# Altium schematic sheet PixelClockController.SchDoc (continued)

## Library data of the parts
BT1 = Battery - CR16XX Retainer: BATT RETAIN COIN 1 CELL PC PIN; Component Kind: Standard; Library Name: main_library.SCHLIB; Supplier 1: Digi-Key; Supplier Part Number 1: BK-869-ND
BZ1 = Piezo Transducer: AUDIO PIEZO TRANSDUCER 12.5V SMD 9 x 9MM; Component Kind: Standard; Library Name: main_library.SCHLIB; Supplier 1: Digi-Key; Supplier Part Number 1: 490-9647-1-ND
C1-C6 C9-C11 C16 C18 = UMJ107BB7104KAHT: CAP CER 0.1UF 50V X7R 0603; Manufacturer 1: Taiyo Yuden; Database Table Name: TaiyoYuden$; Note: Approved sub OK; Tolerance: ±10%; Type: X5R; Component Kind: Standard; Library Name: Ceramic Cap DBLIB.DbLib; Manufacturer Part Number 1: UMJ107BB7104KAHT; Operating Temperature: -55°C ~ 125°C; Package: 0402; Package / Case: 0603 (1608 Metric); Revision: July-2002: Re-released for DXP Platform.; Signal Integrity: Cap Semi; Simulation: CAP; Size / Dimension: 0.063" L x 0.031" W (1.60mm x 0.80mm); Supplier 1: Digi-Key; Supplier Part Number 1: 587-4474-1-ND; Temperature Coefficient: X7R; Thickness (Max): 0.039" (1.00mm); Voltage: 50V
C7 = CapE-6.3-100uF-35V: CAP ALUM 100UF 20% 35V SMD; Note: Approved sub OK; Value: 100uF 35V; Component Kind: Standard; Library Name: main_library.SCHLIB; Published: 8-Jun-2000; Revision: July-2002: Re-released for DXP Platform.; Signal Integrity: Cap Pol; Simulation: CAP; Supplier 1: Digi-Key; Supplier Part Number 1: PCE5016CT-ND; Publisher: Altium Limited
C8 C13 C14 C42 = EMK316ABJ226KL-T: CAP CER 22UF 16V X5R 1206; Manufacturer 1: Taiyo Yuden; Database Table Name: TaiyoYuden$; Note: Approved sub OK; Tolerance: ±10%; Component Kind: Standard; Library Name: Ceramic Cap DBLIB.DbLib; Manufacturer Part Number 1: EMK316ABJ226KL-T; Operating Temperature: -55°C ~ 85°C; Package: 0402; Package / Case: 1206 (3216 Metric); Revision: July-2002: Re-released for DXP Platform.; Signal Integrity: Cap Semi; Simulation: CAP; Size / Dimension: 0.126" L x 0.063" W (3.20mm x 1.60mm); Supplier 1: Digi-Key; Supplier Part Number 1: 587-5521-1-ND; Temperature Coefficient: X5R; Thickness (Max): 0.071" (1.80mm); Type: X5R; Voltage: 16V
C12 = GMJ325KB7106KMHP: CAP CER 10UF 35V X7R 1210; Manufacturer 1: Taiyo Yuden; Database Table Name: TaiyoYuden$; Note: Approved sub OK; Tolerance: ±10%; Type: X5R; Component Kind: Standard; Library Name: Ceramic Cap DBLIB.DbLib; Manufacturer Part Number 1: GMJ325KB7106KMHP; Operating Temperature: -55°C ~ 125°C; Package: 0402; Package / Case: 1210 (3225 Metric); Revision: July-2002: Re-released for DXP Platform.; Signal Integrity: Cap Semi; Simulation: CAP; Size / Dimension: 0.126" L x 0.098" W (3.20mm x 2.50mm); Supplier 1: Digi-Key; Supplier Part Number 1: 587-4890-1-ND; Temperature Coefficient: X7R; Thickness (Max): 0.110" (2.80mm)
C15 = C1608X7R2A222K080AE: CAP CER 2700PF 50V X7R 0603; Manufacturer 1: TDK Corporation; Database Table Name: TDK$; Note: Approved sub OK; Tolerance: ±10%; Type: X5R; Voltage: 100V; Component Kind: Standard; Library Name: Ceramic Cap DBLIB.DbLib; Manufacturer Part Number 1: C1608X7R2A222K080AE; Operating Temperature: -55°C ~ 125°C; Package: 0402; Package / Case: 0603 (1608 Metric); Revision: July-2002: Re-released for DXP Platform.; Signal Integrity: Cap Semi; Simulation: CAP; Size / Dimension: 0.063" L x 0.031" W (1.60mm x 0.80mm); Supplier 1: Digi-Key; Supplier Part Number 1: 1292-1423-2-ND; Temperature Coefficient: X7R; Thickness (Max): 0.035" (0.90mm)
D1 = Diode Schottky 2A 40V (SS24FL): DIODE SCHOTTKY 40V 2A SOD123F; Manufacturer 1: ON Semiconductor; Manufacturer Part Number 1: SS24FL; Code - JEDEC: SOD-123F; Note: Approved sub OK; Component Kind: Standard; Published: 8-Jun-2000; Revision: July-2002: Re-released for DXP Platform.; Signal Integrity: Diode; Simulation: DIODE; Supplier 1: Digi-Key; Supplier Part Number 1: SS24FLCT-ND; Library Name: picoprint_library.SCHLIB; Publisher: Altium Limited
D2 = Diode SK520BTR: DIODE SCHOTTKY 200V 5A SMB; Code - JEDEC: DO-214-AB; Component Kind: Standard; Library Name: main_library.SCHLIB; Published: 8-Jun-2000; Revision: July-2002: Re-released for DXP Platform.; Supplier 1: Digi-Key; Supplier Part Number 1: 1655-1602-1-ND; Publisher: Altium Limited
L1 = Inductor SMD 3.6uH 4.9A: FIXED IND 3.6UH 4.9A 19.5 MOHM; Component Kind: Standard; Library Name: main_library.SCHLIB; Published: 24-Mar-1999; Revision: July-2002: Re-released for DXP Platform.; Supplier 1: Digi-Key; Supplier Part Number 1: 587-1998-1-ND; Publisher: Altium Limited
LD1-LD3 = LEDG  0603: LED GREEN CLEAR CHIP SMD 0603; Note: Approved sub OK; Component Kind: Standard; Library Name: main_library.SCHLIB; Supplier 1: Digi-Key; Supplier Part Number 1: 160-1435-2-ND
P1 P3 P4 P9 P11-P13 P16 P17 = Header 1X2: Header, 2-Pin; Manufacturer 1: Generic Header; Note: Approved sub OK; Library Name: main_library.SCHLIB; Signal Integrity: Connector
  P9: Component Kind: Standard
P2 P5 = Header 2X3: Header, 3-Pin, Dual row; Manufacturer 1: Generic Header; Note: Approved sub OK; Library Name: main_library.SCHLIB; Signal Integrity: Connector
P6 = Header 2X4: Header, 4-Pin, Dual row; Manufacturer 1: Generic Header; Note: Approved sub OK; Library Name: main_library.SCHLIB; Signal Integrity: Connector
P7 = Header 1X6: Header, 6-Pin; Manufacturer 1: Generic Header; Note: Approved sub OK; Component Kind: Standard; Library Name: main_library.SCHLIB; Signal Integrity: Connector
P8 = Header 10X2H: Header, 10-Pin, Dual row, Right Angle; Manufacturer 1: Generic Header; Note: Approved sub OK; Component Kind: Standard; LatestRevisionDate: 17-Jul-2002; LatestRevisionNote: Re-released for DXP Platform.; Library Name: Miscellaneous Connectors.IntLib; Signal Integrity: Connector; Publisher: Altium Limited
Q1 = MOSFET - AO3400A: N-CH MOSFET 30V 5.7A SOT23; Component Kind: Standard; Library Name: main_library.SCHLIB; Supplier 1: Digi-Key; Supplier Part Number 1: 785-1000-1-ND
R1-R4 R8-R10 R15 = RC0603FR-071KL: RES SMD 1K OHM 1% 1/10W 0603; Manufacturer 1: Yageo; Database Table Name: 0603$; Note: Approved sub OK; Power (Watts): 0.1W, 1/10W; Temperature Coefficient: ±100ppm/°C; Component Kind: Standard; Composition: Thick Film; Features: Moisture Resistant; Height - Seated (Max): 0.022" (0.55mm); Library Name: Database_Libs1.DbLib; Manufacturer Part Number 1: RC0603FR-071KL; Operating Temperature: -55°C ~ 155°C; Package / Case: 0603 (1608 Metric); Published: 8-Jun-2000; Revision: July-2002: Re-released for DXP Platform.; Signal Integrity: Res; Simulation: RESISTOR; Size / Dimension: 0.063" L x 0.031" W (1.60mm x 0.80mm); Supplier 1: Digi-Key; Supplier Part Number 1: 311-1.00KHRCT-ND; Tolerance: ±1%; Publisher: Altium Limited
R5 = RC0603FR-07330RL: RES SMD 330 OHM 1% 1/10W 0603; Manufacturer 1: Yageo; Database Table Name: 0603$; Note: Approved sub OK; Power (Watts): 0.1W, 1/10W; Temperature Coefficient: ±100ppm/°C; Component Kind: Standard; Composition: Thick Film; Features: Moisture Resistant; Height - Seated (Max): 0.022" (0.55mm); Library Name: Database_Libs1.DbLib; Manufacturer Part Number 1: RC0603FR-07330RL; Operating Temperature: -55°C ~ 155°C; Package / Case: 0603 (1608 Metric); Published: 8-Jun-2000; Revision: July-2002: Re-released for DXP Platform.; Signal Integrity: Res; Simulation: RESISTOR; Size / Dimension: 0.063" L x 0.031" W (1.60mm x 0.80mm); Supplier 1: Digi-Key; Supplier Part Number 1: 311-330HRCT-ND; Tolerance: ±1%; Publisher: Altium Limited
R6 R7 R18 R23-R25 R29-R32 = RC0603FR-0710KL: RES SMD 10K OHM 1% 1/10W 0603; Manufacturer 1: Yageo; Database Table Name: 0603$; Note: Approved sub OK; Power (Watts): 0.1W, 1/10W; Temperature Coefficient: ±100ppm/°C; Component Kind: Standard; Composition: Thick Film; Features: Moisture Resistant; Height - Seated (Max): 0.022" (0.55mm); Library Name: Database_Libs1.DbLib; Manufacturer Part Number 1: RC0603FR-0710KL; Operating Temperature: -55°C ~ 155°C; Package / Case: 0603 (1608 Metric); Published: 8-Jun-2000; Revision: July-2002: Re-released for DXP Platform.; Signal Integrity: Res; Simulation: RESISTOR; Size / Dimension: 0.063" L x 0.031" W (1.60mm x 0.80mm); Supplier 1: Digi-Key; Supplier Part Number 1: 311-10.0KHRCT-ND; Tolerance: ±1%; Publisher: Altium Limited
R11 R35 = Res-0603-0R: RES 0 OHM JUMPER 1/10W 0603; Note: Approved sub OK; Component Kind: Standard; Library Name: main_library.SCHLIB; Published: 8-Jun-2000; Revision: July-2002: Re-released for DXP Platform.; Signal Integrity: Res; Simulation: RESISTOR; Supplier 1: Digi-Key; Supplier Part Number 1: 311-0.0GRCT-ND; Publisher: Altium Limited
R12 = RC0603FR-07115KL: RES SMD 115K OHM 1% 1/10W 0603; Manufacturer 1: Yageo; Database Table Name: 0603$; Note: Approved sub OK; Power (Watts): 0.1W, 1/10W; Temperature Coefficient: ±100ppm/°C; Component Kind: Standard; Composition: Thick Film; Features: Moisture Resistant; Height - Seated (Max): 0.022" (0.55mm); Library Name: Database_Libs1.DbLib; Manufacturer Part Number 1: RC0603FR-07115KL; Operating Temperature: -55°C ~ 155°C; Package / Case: 0603 (1608 Metric); Published: 8-Jun-2000; Revision: July-2002: Re-released for DXP Platform.; Signal Integrity: Res; Simulation: RESISTOR; Size / Dimension: 0.063" L x 0.031" W (1.60mm x 0.80mm); Supplier 1: Digi-Key; Supplier Part Number 1: 311-115KHRCT-ND; Tolerance: ±1%; Publisher: Altium Limited
R13 = RC0603FR-07200KL: RES SMD 200K OHM 1% 1/10W 0603; Manufacturer 1: Yageo; Database Table Name: 0603$; Note: Approved sub OK; Power (Watts): 0.1W, 1/10W; Temperature Coefficient: ±100ppm/°C; Component Kind: Standard; Composition: Thick Film; Features: Moisture Resistant; Height - Seated (Max): 0.022" (0.55mm); Library Name: Database_Libs1.DbLib; Manufacturer Part Number 1: RC0603FR-07200KL; Operating Temperature: -55°C ~ 155°C; Package / Case: 0603 (1608 Metric); Published: 8-Jun-2000; Revision: July-2002: Re-released for DXP Platform.; Signal Integrity: Res; Simulation: RESISTOR; Size / Dimension: 0.063" L x 0.031" W (1.60mm x 0.80mm); Supplier 1: Digi-Key; Supplier Part Number 1: 311-200KHRCT-ND; Tolerance: ±1%; Publisher: Altium Limited
R14 = RC0603FR-0715K8L: RES SMD 15.8K OHM 1% 1/10W 0603; Manufacturer 1: Yageo; Database Table Name: 0603$; Note: Approved sub OK; Power (Watts): 0.1W, 1/10W; Temperature Coefficient: ±100ppm/°C; Component Kind: Standard; Composition: Thick Film; Features: Moisture Resistant; Height - Seated (Max): 0.022" (0.55mm); Library Name: Database_Libs1.DbLib; Manufacturer Part Number 1: RC0603FR-0715K8L; Operating Temperature: -55°C ~ 155°C; Package / Case: 0603 (1608 Metric); Published: 8-Jun-2000; Revision: July-2002: Re-released for DXP Platform.; Signal Integrity: Res; Simulation: RESISTOR; Size / Dimension: 0.063" L x 0.031" W (1.60mm x 0.80mm); Supplier 1: Digi-Key; Supplier Part Number 1: 311-15.8KHRCT-ND; Tolerance: ±1%; Publisher: Altium Limited
R16 R17 R20-R22 R26-R28 R33 R34 R36 = RC0603FR-07100RL: RES SMD 100 OHM 1% 1/10W 0603; Manufacturer 1: Yageo; Database Table Name: 0603$; Note: Approved sub OK; Power (Watts): 0.1W, 1/10W; Temperature Coefficient: ±100ppm/°C; Component Kind: Standard; Composition: Thick Film; Features: Moisture Resistant; Height - Seated (Max): 0.022" (0.55mm); Library Name: Database_Libs1.DbLib; Manufacturer Part Number 1: RC0603FR-07100RL; Operating Temperature: -55°C ~ 155°C; Package / Case: 0603 (1608 Metric); Published: 8-Jun-2000; Revision: July-2002: Re-released for DXP Platform.; Signal Integrity: Res; Simulation: RESISTOR; Size / Dimension: 0.063" L x 0.031" W (1.60mm x 0.80mm); Supplier 1: Digi-Key; Supplier Part Number 1: 311-100HRCT-ND; Tolerance: ±1%; Publisher: Altium Limited
R19 = RC0603FR-0722K1L: RES SMD 22.1K OHM 1% 1/10W 0603; Manufacturer 1: Yageo; Database Table Name: 0603$; Note: Approved sub OK; Power (Watts): 0.1W, 1/10W; Temperature Coefficient: ±100ppm/°C; Component Kind: Standard; Composition: Thick Film; Features: Moisture Resistant; Height - Seated (Max): 0.022" (0.55mm); Library Name: Database_Libs1.DbLib; Manufacturer Part Number 1: RC0603FR-0722K1L; Operating Temperature: -55°C ~ 155°C; Package / Case: 0603 (1608 Metric); Published: 8-Jun-2000; Revision: July-2002: Re-released for DXP Platform.; Signal Integrity: Res; Simulation: RESISTOR; Size / Dimension: 0.063" L x 0.031" W (1.60mm x 0.80mm); Supplier 1: Digi-Key; Supplier Part Number 1: 311-22.1KHRCT-ND; Tolerance: ±1%; Publisher: Altium Limited
S1-S5 = SW_THT_PB_4.3mm: 6 X 6 MM, 12 MM ACTUATOR HEIGHT; Manufacturer 1: CUI Devices; Manufacturer Part Number 1: TS02-66-120-BK-160-LCR-D; Component Kind: Standard; Library Name: PBL - Switches.SCHLIB; Material: Brass/Nylon/Ag plating; Package / Case: 6.5x6.5mm; 4.3mm stem; Supplier 1: Digi-Key; Supplier Part Number 1: 2223-TS02-66-120-BK-160-LCR-D-ND
TP1 = TESTPOINT: SMD test pin; Library Name: PBL - Test Points.SCHLIB
U1 = Regulator - AP2112K 3.3V Linear Regulator: IC REG LINEAR 3.3V 600MA SOT25; Manufacturer 1: Diodes Incorporated; Component Kind: Standard; Manufacturer Part Number 1: AP2112K-3.3TRG1; Supplier 1: Digi-Key; Supplier Part Number 1: AP2112K-3.3TRG1DICT-ND; Library Name: picoprint_library.SCHLIB
U2 U7 = Buffer Non-Inverting Schmitt Trigger 74LVC1G17SE-7: IC BUF NON-INVERT 5.5V SOT353; Component Kind: Standard; Supplier 1: Digi-Key; Supplier Part Number 1: 74LVC1G17SE-7CT-ND; Library Name: picoprint_library.SCHLIB
U3 = IC - Optical Sensor Ambient - I²C 6-WFDFN: SENSOR OPT AMBIENT 6DFN; Component Kind: Standard; Library Name: main_library.SCHLIB; Supplier 1: Digi-Key; Supplier Part Number 1: TSL25911FNCT-ND
U4 = IC - DS3231 RTC: IC RTC CLK/CALENDAR I2C 16SOIC; Component Kind: Standard; Library Name: main_library.SCHLIB; Supplier 1: Digi-Key; Supplier Part Number 1: DS3231S#T&RCT-ND
U5 = Regulator - AP64500 Buck Regulator: IC DCDC CONV HV BUCK SO-8EP; Component Kind: Standard; Library Name: main_library.SCHLIB; Supplier 1: Digi-Key; Supplier Part Number 1: 31-AP64500SP-13CT-ND
U6 = ESP32-DEVKIT-C V4: ESP32-WROVER-E 8MB FLASH; Component Kind: Standard; Library Name: main_library.SCHLIB; Supplier 1: Digi-Key; Supplier Part Number 1: 1965-ESP32-DEVKITC-VE-ND
U8 = IC - I2S Mic - SPH0645LM4H: MIC MEMS DIGITAL I2S OMNI -26DB; Component Kind: Standard; Library Name: main_library.SCHLIB; Supplier 1: Digi-Key; Supplier Part Number 1: 423-SPH0645LM4H-BCT-ND
MH1-MH4 = Screw Hole: Library Name: main_library.SCHLIB
